# Altium schematic sheet MaPan.SchDoc(MaPan)
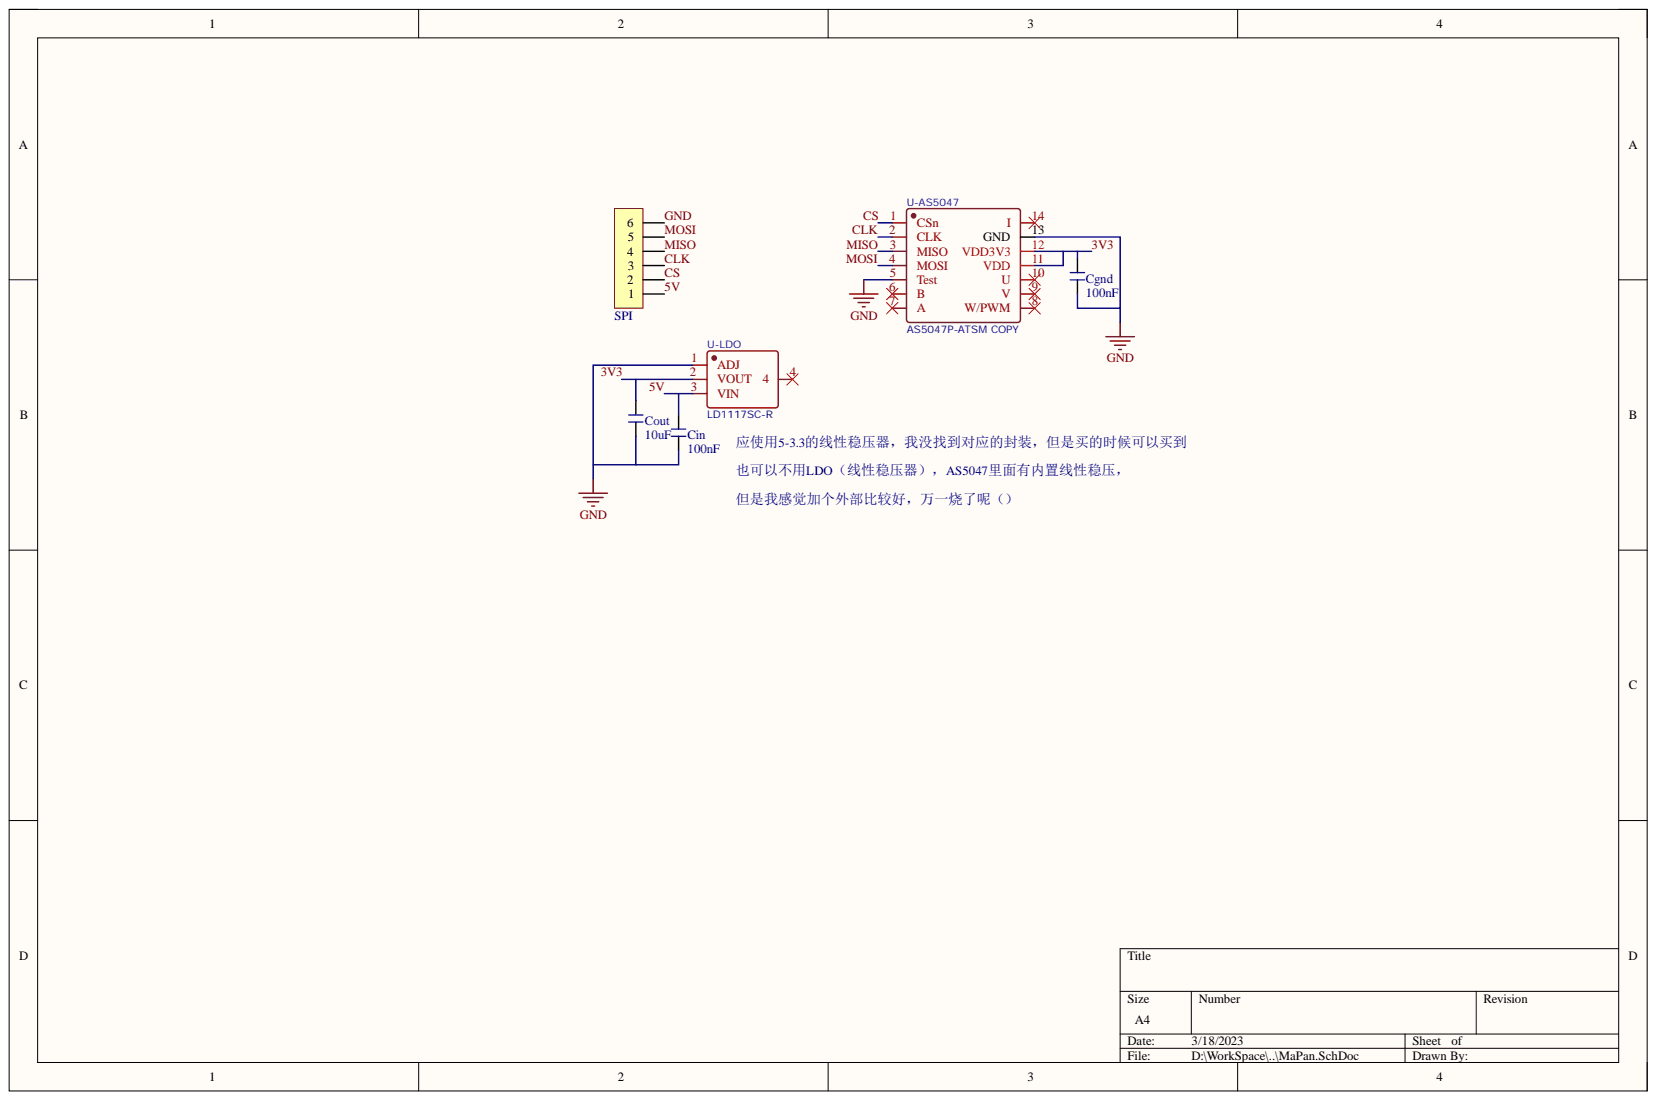
[diagram p0: MaPan.SchDoc]

## Parts
Cgnd  100nF  [C 0603_M]
Cin  100nF  [C 0603_M]
Cout  10uF  [C 0603_M]
SPI  HDR-1X6  [GH1.25-WS-6P]  (value not drawn)
U-AS5047  AS5047P-ATSM COPY  [TSSOP-14_L5.0-W4.4-P0.65-LS6.4-BL]
U-LDO  LD1117SC-R  [SOT-223-3_L6.5-W3.4-P2.30-LS7.0-BR]

## Nets
3V3: Cgnd.2 Cout.2 U-AS5047.11(VDD) U-AS5047.12(VDD3V3) U-LDO.2(VOUT)
5V: Cin.2 SPI.1 U-LDO.3(VIN)
CLK: SPI.3 U-AS5047.2(CLK)
CS: SPI.2 U-AS5047.1(CSn)
GND: Cgnd.1 Cin.1 Cout.1 SPI.6 U-AS5047.5(Test) U-AS5047.13(GND) U-LDO.1(ADJ)
MISO: SPI.4 U-AS5047.3(MISO)
MOSI: SPI.5 U-AS5047.4(MOSI)

Not connected: U-AS5047 6(B) 7(A) 8(W/PWM) 9(V) 10(U) 14(I); U-LDO 4

## Library data of the parts
Cgnd Cin Cout = C: 贴片电容; 淘宝ID: 小糖豆的小窝窝; BOM名称: 贴片电容; Component Kind: Standard; Library Name: 01 - 电容-电阻-电感.SchLib; 下单链接: https://item.taobao.com/item.htm?ft=t&id=613637044352
SPI = Header 6: 6P接插件; 淘宝ID: 小糖豆的小窝窝; BOM名称: 6P接插件; Component Kind: Standard; Library Name: 16 - 接插件-脚距1.5mm.SchLib; 下单链接: https://item.taobao.com/item.htm?ft=t&id=613637044352
U-AS5047 = AS5047P-ATSM COPY: Component Kind: Standard; Library Name: AS5047P.IntLib
U-LDO = LD1117SC-R: Component Kind: Standard; Library Name: LDO1117.IntLib
